# Altium schematic sheet Power.SchDoc(Power)
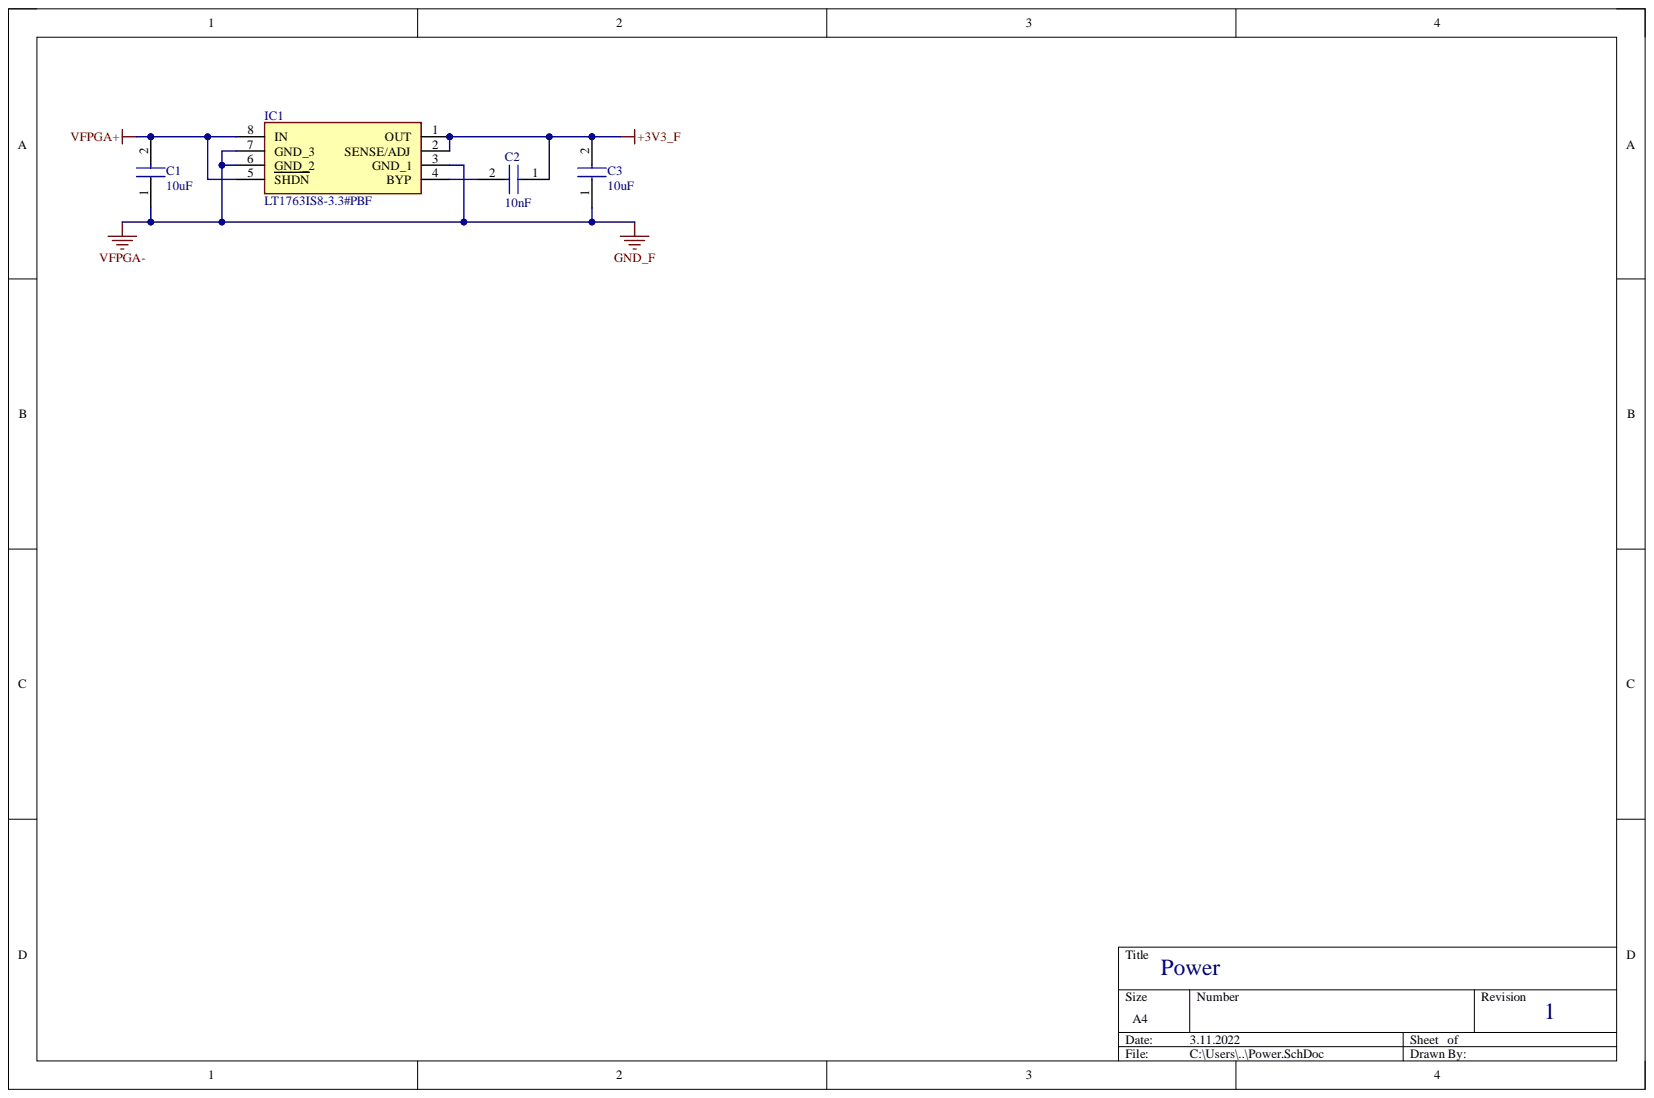
[diagram p0: Power.SchDoc]

## Parts
C1  10uF  [CAPC2012X95N]
C2  10nF  [CAPC2012X95N]
C3  10uF  [CAPC2012X95N]
IC1  LT1763IS8-3.3#PBF  [SOIC127P599X175-8N]

## Nets
+3V3_F: C2.1 C3.2 IC1.1(OUT) IC1.2(SENSE/ADJ)
GND_F: C1.1 C3.1 IC1.3(GND_1) IC1.6(GND_2) IC1.7(GND_3)
VFPGA+: C1.2 IC1.5(SHDN) IC1.8(IN)
NetC2_2: C2.2 IC1.4(BYP)

## Library data of the parts
C1 C3 = CL21A106KOCLRNC: Capacitor; Manufacturer_Name: Samsung Electro-Mechanics; Arrow Part Number: CL21A106KOCLRNC; Arrow Price/Stock: https://www.arrow.com/en/products/cl21a106koclrnc/samsung-electro-mechanics?region=europe; Component Kind: Standard; Datasheet Link: https://datasheet.lcsc.com/szlcsc/Samsung-Electro-Mechanics-CL21A106KOCLRNC_C318695.pdf; Height: 0.95mm; Library Name: SamacSys.SchLib; Manufacturer_Part_Number: CL21A106KOCLRNC; Mouser Part Number: 187-CL21A106KOCLRNC; Mouser Price/Stock: https://www.mouser.co.uk/ProductDetail/Samsung-Electro-Mechanics/CL21A106KOCLRNC?qs=yOVawPpwOwk0TtWGO3Tj1g%3D%3D
C2 = CL21B103KBCNNNC: Capacitor; Manufacturer_Name: Samsung Electro-Mechanics; Arrow Part Number: CL21B103KBCNNNC; Arrow Price/Stock: https://www.arrow.com/en/products/cl21b103kbcnnnc/samsung-electro-mechanics?region=nac; Component Kind: Standard; Datasheet Link: https://datasheet.datasheetarchive.com/originals/distributors/Datasheets_SAMA/585830b381ae100e9c9ca06e52b8f281.pdf; Height: 0.95mm; Manufacturer_Part_Number: CL21B103KBCNNNC; Mouser Part Number: 187-CL21B103KBCNNNC; Mouser Price/Stock: https://www.mouser.co.uk/ProductDetail/Samsung-Electro-Mechanics/CL21B103KBCNNNC?qs=%252B6g0mu59x7J40x%2F08JptpA%3D%3D
IC1 = LT1763IS8-3.3#PBF: Integrated Circuit; Manufacturer_Name: Linear Technology; Component Kind: Standard; Datasheet Link: https://datasheet.datasheetarchive.com/originals/distributors/Datasheets-SFU4/DSASF4000-3503.pdf; Height: 1.752mm; Library Name: SamacSys.SchLib; Manufacturer_Part_Number: LT1763IS8-3.3#PBF; Mouser Part Number: 584-LT1763IS8-3.3PBF; Mouser Price/Stock: https://www.mouser.co.uk/ProductDetail/Analog-Devices-Linear-Technology/LT1763IS8-33PBF?qs=ytflclh7QUXoCHefIThm8A%3D%3D
